# Altium schematic sheet LM3409_LED1.SchDoc
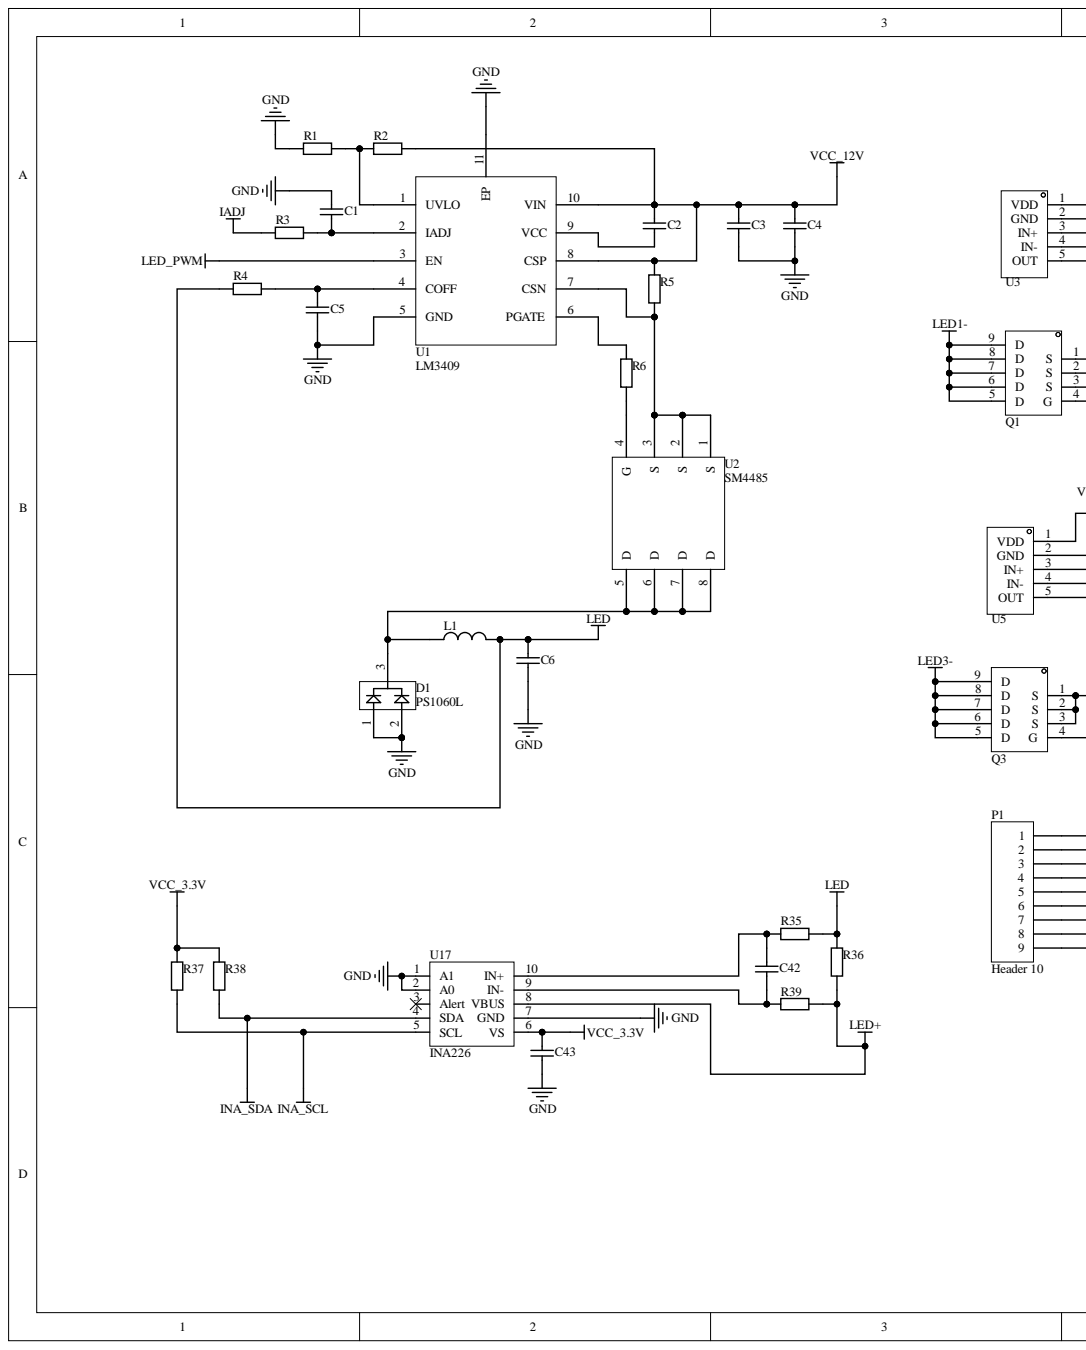
[diagram p0: LM3409_LED1.SchDoc, left]
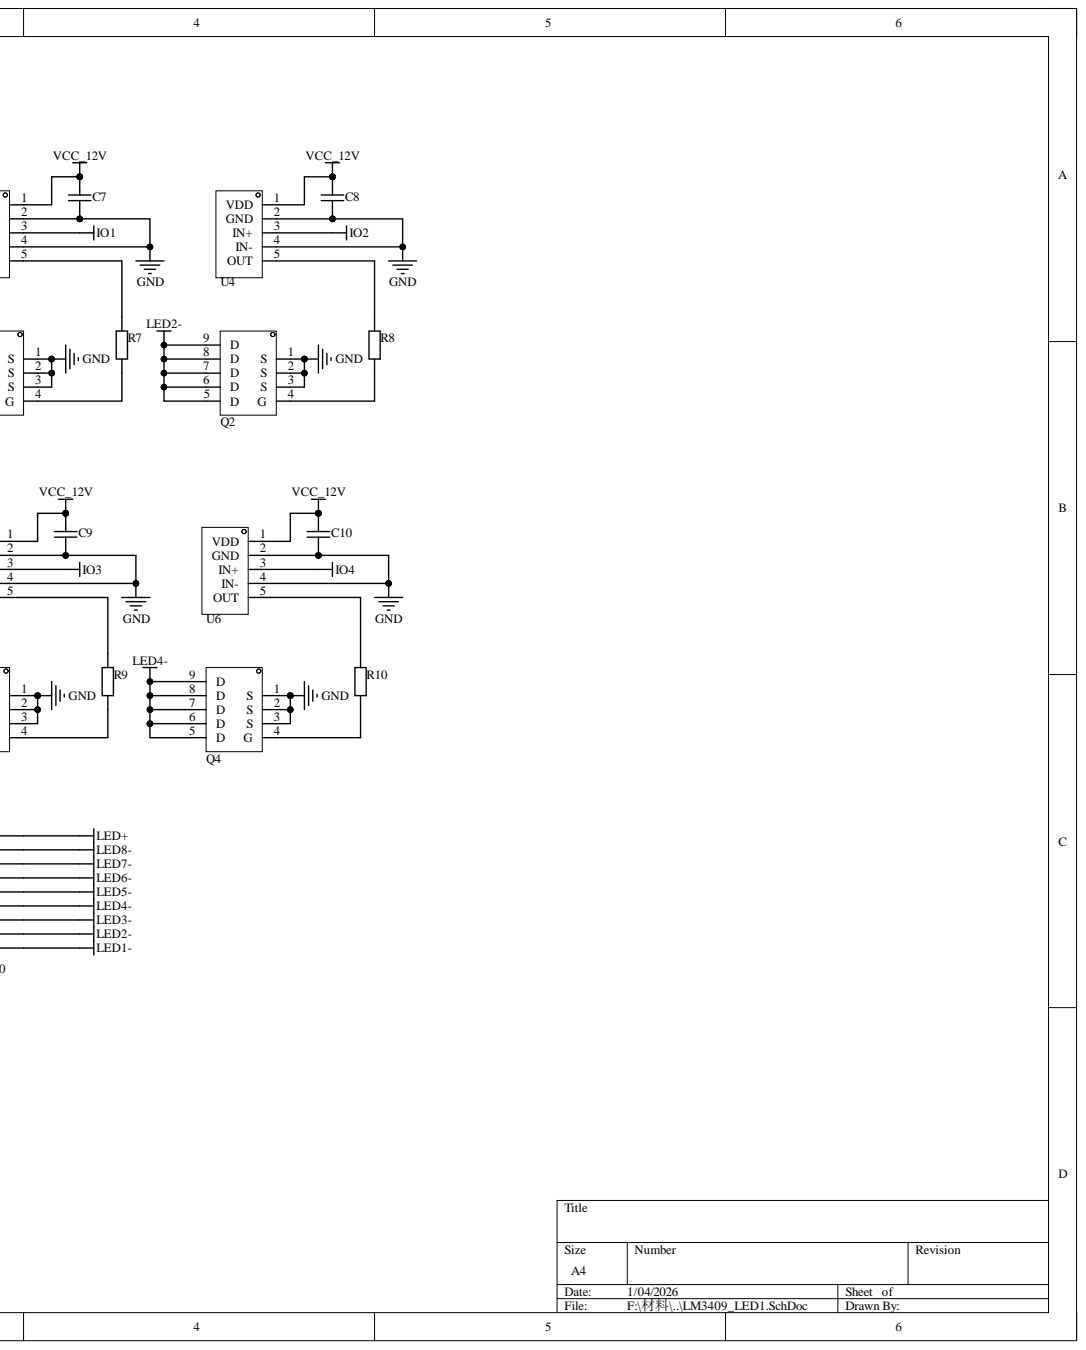
[diagram p1: LM3409_LED1.SchDoc, right]

## Parts
C1  0.1uF  [C-RAD-0603]  (value not drawn)
C2  1uF  [C-RAD-0603]  (value not drawn)
C3  2.2uF  [C-RAD-0603]  (value not drawn)
C4  2.2uF  [C-RAD-0603]  (value not drawn)
C5  470pF  [C-RAD-0603]  (value not drawn)
C6  220nF  [C-RAD-0603]  (value not drawn)
C7  10uF  [C-RAD-0603]  (value not drawn)
C8  10uF  [C-RAD-0603]  (value not drawn)
C9  10uF  [C-RAD-0603]  (value not drawn)
C10  10uF  [C-RAD-0603]  (value not drawn)
C42  Cap  [C-RAD-0603]  (value not drawn)
C43  Cap  [C-RAD-0603]  (value not drawn)
D1  PS1060L  [PS-277B]
L1  47uH  [IND-SMD_L11.5-W10.0]  (value not drawn)
P1  Header 10  [XH2.54-WI-9P]
Q1  CSD17579Q3A  [SON-8_L3.0-W3.1-P0.65-BP-EP]  (value not drawn)
Q2  CSD17579Q3A  [SON-8_L3.0-W3.1-P0.65-BP-EP]  (value not drawn)
Q3  CSD17579Q3A  [SON-8_L3.0-W3.1-P0.65-BP-EP]  (value not drawn)
Q4  CSD17579Q3A  [SON-8_L3.0-W3.1-P0.65-BP-EP]  (value not drawn)
R1  6.98KΩ  [0603-1]  (value not drawn)
R2  49.9KΩ  [0603-1]  (value not drawn)
R3  1KΩ  [0603-1]  (value not drawn)
R4  6.65KΩ  [0603-1]  (value not drawn)
R5  160mΩ  [2512]  (value not drawn)
R6  2Ω  [0603-1]  (value not drawn)
R7  2Ω  [0603-1]  (value not drawn)
R8  2Ω  [0603-1]  (value not drawn)
R9  2Ω  [0603-1]  (value not drawn)
R10  2Ω  [0603-1]  (value not drawn)
R35  10  [0603-1]  (value not drawn)
R36  20mΩ  [2512]  (value not drawn)
R37  4.7KΩ  [0603-1]  (value not drawn)
R38  4.7KΩ  [0603-1]  (value not drawn)
R39  10  [0603-1]  (value not drawn)
U1  LM3409  [DGQ0010A_N]
U2  SM4485  [SOP-8]
U3  UCC27517DBVR  [SOT-23-5_L3.0-W1.7-P0.95-LS2.8-BR]  (value not drawn)
U4  UCC27517DBVR  [SOT-23-5_L3.0-W1.7-P0.95-LS2.8-BR]  (value not drawn)
U5  UCC27517DBVR  [SOT-23-5_L3.0-W1.7-P0.95-LS2.8-BR]  (value not drawn)
U6  UCC27517DBVR  [SOT-23-5_L3.0-W1.7-P0.95-LS2.8-BR]  (value not drawn)
U17  INA226  [SOP-10]

## Nets
GND: C1.1 C3.1 C4.1 C5.1 C6.1 C7.1 C8.1 C9.1 C10.1 C43.1 D1.1 D1.2 Q1.1(S) Q1.2(S) Q1.3(S) Q2.1(S) Q2.2(S) Q2.3(S) Q3.1(S) Q3.2(S) Q3.3(S) Q4.1(S) Q4.2(S) Q4.3(S) R1.1 U1.5(GND) U1.11(EP) U3.2(GND) U3.4(IN-) U4.2(GND) U4.4(IN-) U5.2(GND) U5.4(IN-) U6.2(GND) U6.4(IN-) U17.1(A1) U17.2(A0) U17.7(GND)
IADJ: R3.1
INA_SCL: R37.1 U17.5(SCL)
INA_SDA: R38.1 U17.4(SDA)
IO1: U3.3(IN+)
IO2: U4.3(IN+)
IO3: U5.3(IN+)
IO4: U6.3(IN+)
LED: C6.2 L1.2 R4.1 R35.1 R36.2
LED1-: P1.9 Q1.5(D) Q1.6(D) Q1.7(D) Q1.8(D) Q1.9(D)
LED2-: P1.8 Q2.5(D) Q2.6(D) Q2.7(D) Q2.8(D) Q2.9(D)
LED3-: P1.7 Q3.5(D) Q3.6(D) Q3.7(D) Q3.8(D) Q3.9(D)
LED4-: P1.6 Q4.5(D) Q4.6(D) Q4.7(D) Q4.8(D) Q4.9(D)
LED5-: P1.5
LED6-: P1.4
LED7-: P1.3
LED8-: P1.2
LED+: P1.1 R36.1 R39.1 U17.8(VBUS)
LED_PWM: U1.3(EN)
VCC_3.3V: C43.2 R37.2 R38.2 U17.6(VS)
VCC_12V: C2.2 C3.2 C4.2 C7.2 C8.2 C9.2 C10.2 R2.2 R5.2 U1.8(CSP) U1.10(VIN) U3.1(VDD) U4.1(VDD) U5.1(VDD) U6.1(VDD)
NetC1_2: C1.2 R3.2 U1.2(IADJ)
NetC2_1: C2.1 U1.9(VCC)
NetC5_2: C5.2 R4.2 U1.4(COFF)
NetC42_1: C42.1 R39.2 U17.9(IN-)
NetC42_2: C42.2 R35.2 U17.10(IN+)
NetD1_3: D1.3 L1.1 U2.5(D) U2.6(D) U2.7(D) U2.8(D)
NetQ1_4: Q1.4(G) R7.1
NetQ2_4: Q2.4(G) R8.1
NetQ3_4: Q3.4(G) R9.1
NetQ4_4: Q4.4(G) R10.1
NetR1_2: R1.2 R2.1 U1.1(UVLO)
NetR5_1: R5.1 U1.7(CSN) U2.1(S) U2.2(S) U2.3(S)
NetR6_1: R6.1 U2.4(G)
NetR6_2: R6.2 U1.6(PGATE)
NetR7_2: R7.2 U3.5(OUT)
NetR8_2: R8.2 U4.5(OUT)
NetR9_2: R9.2 U5.5(OUT)
NetR10_2: R10.2 U6.5(OUT)

Not connected: U17 3(Alert)

## Library data of the parts
C1-C10 = Cap: 无极性电容; Component Kind: Standard; LatestRevisionDate: 17-Jul-2002; LatestRevisionNote: Re-released for DXP Platform.; PackageReference: RAD-0.3; Published: 8-Jun-2000; Library Name: Motro_ChangeLED.SchLib; Publisher: Altium Limited
C42 C43 = Cap: 0.1uF; Component Kind: Standard; LatestRevisionDate: 17-Jul-2002; LatestRevisionNote: Re-released for DXP Platform.; Library Name: INA226.SchLib; PackageReference: RAD-0.3; Published: 8-Jun-2000; Publisher: Altium Limited
D1 = PS1060L: 肖特基二极管; Component Kind: Standard; Library Name: Motro_ChangeLED.SchLib
L1 = Inductor: 电感; Code_IEC: 1005; Code_JEITA: 0402; Component Kind: Standard; LatestRevisionDate: 17-Jul-2002; LatestRevisionNote: Re-released for DXP Platform.; PackageReference: 0402-A; Published: 8-Jun-2000; Library Name: Motro_ChangeLED.SchLib; Publisher: Altium Limited
P1 = Header 9: Header, 9-Pin; Component Kind: Standard; LatestRevisionDate: 17-Jul-2002; LatestRevisionNote: Re-released for DXP Platform.; Library Name: Motro_ChangeLED.SchLib; Publisher: Altium Limited
Q1-Q4 = CSD17579Q3A: 大功率NMOS; Manufacturer: TI(德州仪器); Add into BOM: yes; Continuous Drain Current (Id): 20A; Drain Source On Resistance (RDS(on)@Vgs,Id): 10.2mΩ@10V,8A; Drain Source Voltage (Vdss): 30V; Gate Threshold Voltage (Vgs(th)@Id): 1.9V@250uA; Power Dissipation (Pd): 3.2W; Type: N沟道; Component Kind: Standard; Convert to PCB: yes; Datasheet: https://www.ti.com/cn/lit/gpn/csd17579q3a; Device: CSD17579Q3A; JLCPCB Part Class: Extended Part; LCSC Part Name: N沟道 耐压:30V 电流:20A; Manufacturer Part: CSD17579Q3A; Name: CSD17579Q3A; PCB3D: SON-8_L3.0-W3.1-H1.0-P0.65-BP-EP; Supplier: LCSC; Supplier Footprint: SON-8-EP(3.1x3); Supplier Part: C97376; Symbol: CSD17579Q3A; Library Name: Motro_ChangeLED.SchLib
R1-R10 R35 R37-R39 = Res1: 贴片电阻; Component Kind: Standard; LatestRevisionDate: 17-Jul-2002; LatestRevisionNote: Re-released for DXP Platform.; PackageReference: AXIAL-0.4; Published: 8-Jun-2000; Publisher: Altium Limited
  R35 R37-R39: Library Name: INA226.SchLib
  R1-R10: Library Name: Motro_ChangeLED.SchLib
R36 = Res1: 20毫欧; Component Kind: Standard; LatestRevisionDate: 17-Jul-2002; LatestRevisionNote: Re-released for DXP Platform.; Library Name: INA226.SchLib; PackageReference: AXIAL-0.4; Published: 8-Jun-2000; Publisher: Altium Limited
U1 = LM3409: 用于高功率 LED 驱动器的 PFET 降压控制器; Component Kind: Standard; Library Name: Motro_ChangeLED.SchLib
U2 = SM4485: P沟道 40V 10A; Component Kind: Standard; Library Name: Motro_ChangeLED.SchLib
U3-U6 = UCC27517DBVR: 栅极驱动芯片; Manufacturer: TI(德州仪器); Add into BOM: yes; Driven Configuration: 低边; Fall Time: 7ns; Load Type: MOSFET；IGBT; Number of Drivers: 1; Peak Output Current(sink): 4A; Rise Time: 8ns; Supply Voltage: 4.5V~18V; Component Kind: Standard; Convert to PCB: yes; Datasheet: https://atta.szlcsc.com/upload/public/pdf/source/20230222/1212423ADE166F7F12C6FA618B9CDF22.pdf; Device: UCC27517DBVR; JLCPCB Part Class: Extended Part; LCSC Part Name: 低边 IGBT MOSFET 灌:4A 拉:4A; Manufacturer Part: UCC27517DBVR; Name: UCC27517DBVR; Operating Temperature: -40℃~+140℃@(Tj); PCB3D: SOT-23-5_L3.0-W1.7-P0.95-LS2.8-BR; Peak Output Current(source): 4A; Supplier: LCSC; Supplier Footprint: SOT-23-5; Supplier Part: C99395; Symbol: UCC27517DBVR; Library Name: Motro_ChangeLED.SchLib
U17 = INA226: 电流监测芯片; Component Kind: Standard; Library Name: INA226.SchLib
